# Altium schematic sheet RP2350A.SchDoc(RP2350A)
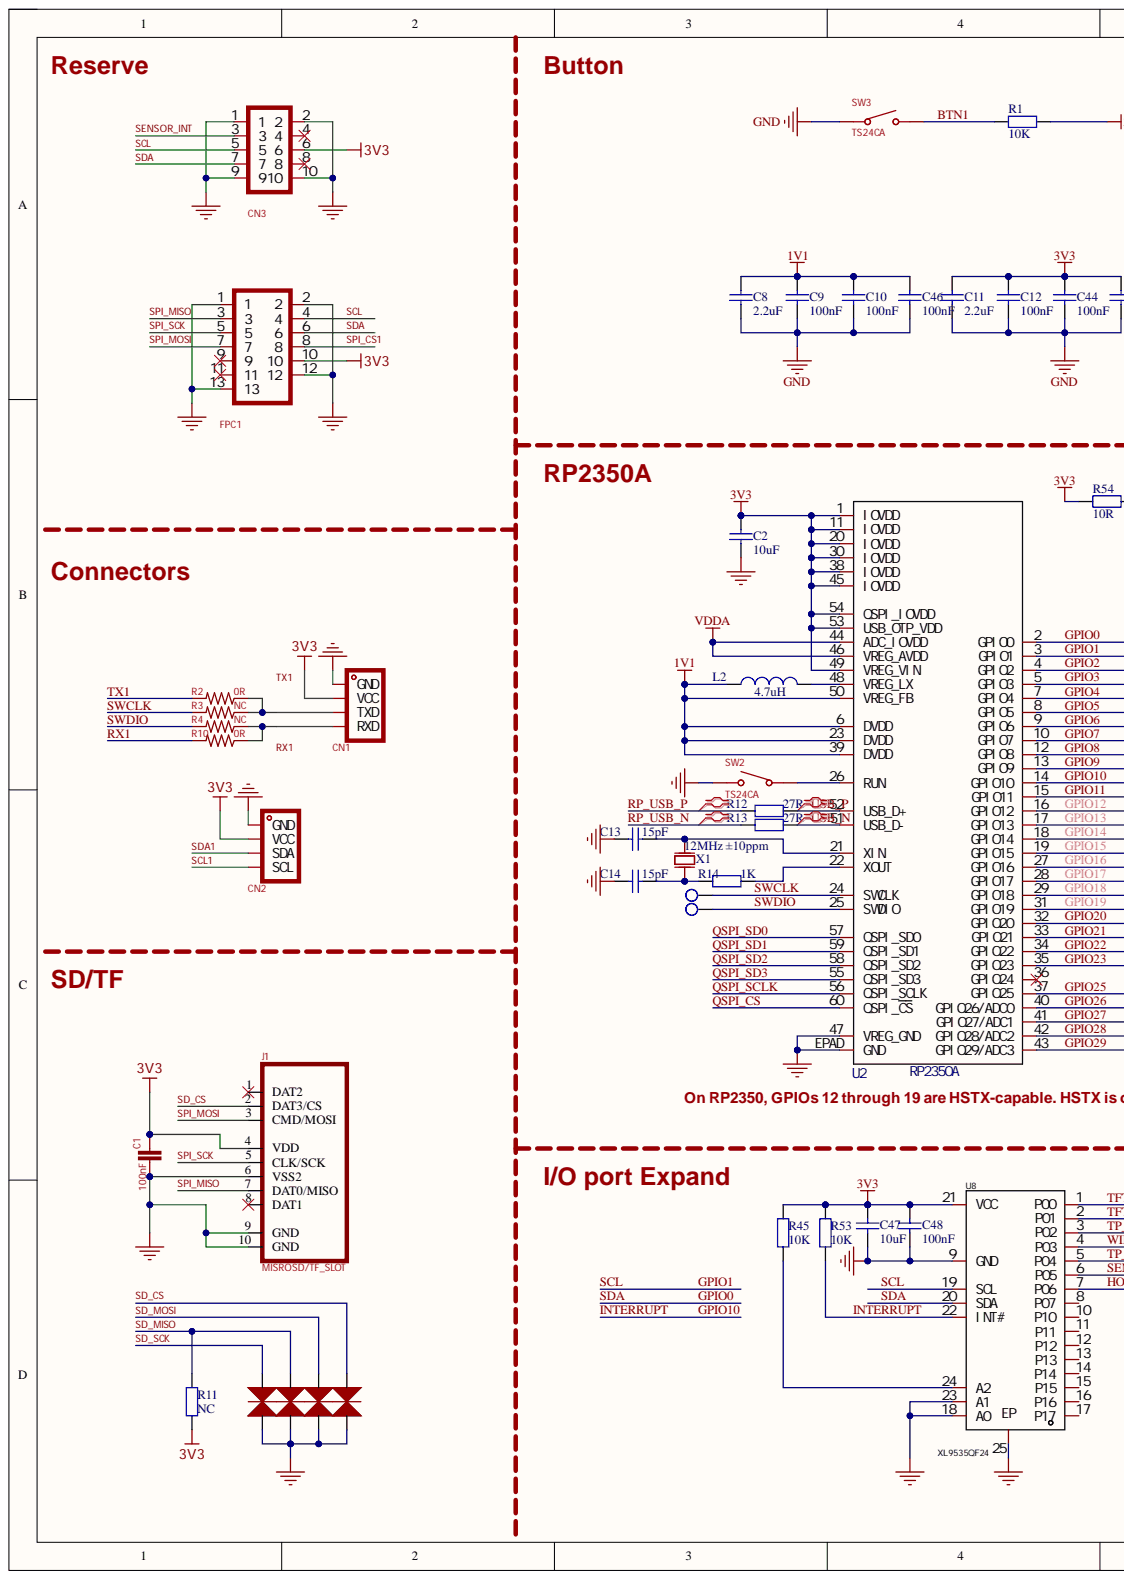
[diagram p0: RP2350A.SchDoc, left]
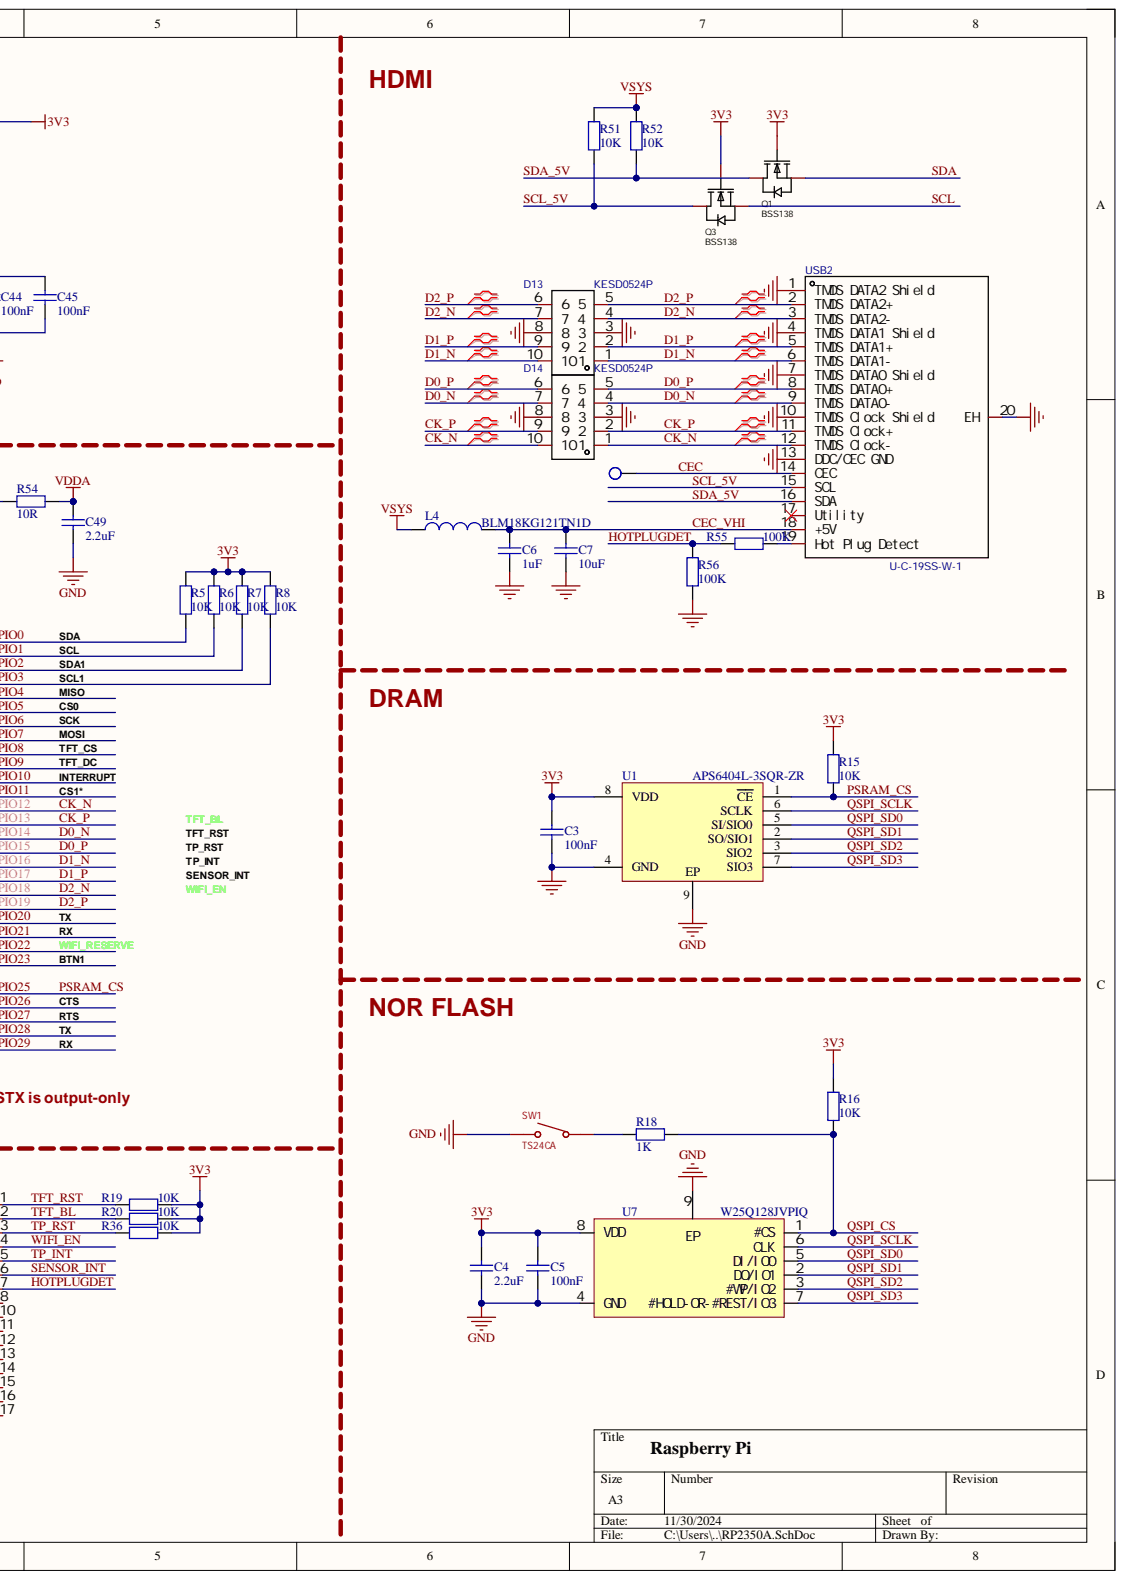
[diagram p1: RP2350A.SchDoc, right]

## Parts
C1  100nF  [C0402]
C2  10uF  [C0402]
C3  100nF  [C0402]
C4  2.2uF  [C0402]
C5  100nF  [C0402]
C6  1uF  [C0402]
C7  10uF  [C0402]
C8  2.2uF  [C0402]
C9  100nF  [C0402]
C10  100nF  [C0402]
C11  2.2uF  [C0402]
C12  100nF  [C0402]
C13  15pF  [C0402]
C14  15pF  [C0402]
C44  100nF  [C0402]
C45  100nF  [C0402]
C46  100nF  [C0402]
C47  10uF  [C0402]
C48  100nF  [C0402]
C49  2.2uF  [C0402]
CN1  BM04B-SRSS-TBT(LF)(SN)  [CONN-SMD_A1002WR-S-4P]  (value not drawn)
CN2  BM04B-SRSS-TBT(LF)(SN)  [CONN-SMD_A1002WR-S-4P]  (value not drawn)
CN3  DF37NC-10DS-0.4V(51)  [CONN-SMD_DF37NC-10DS-0.4V-51]  (value not drawn)
D1  ESD05V88D-LC  [DFN-SMD_L1.0-W0.6-BI]  (value not drawn)
D2  ESD05V88D-LC  [DFN-SMD_L1.0-W0.6-BI]  (value not drawn)
D3  ESD05V88D-LC  [DFN-SMD_L1.0-W0.6-BI]  (value not drawn)
D4  ESD05V88D-LC  [DFN-SMD_L1.0-W0.6-BI]  (value not drawn)
D13  KESD0524P  [DFN2510-10_L2.5-W1.0-P0.50-BL]
D14  KESD0524P  [DFN2510-10_L2.5-W1.0-P0.50-BL]
FPC1  FH26W-13S-0.3SHW(60)  [FPC-SMD_FH26W-13S-0.3SHW-60]  (value not drawn)
J1  MISROSD/TF_SLOT  [TF_5]
L2  4.7uH  [IND-SMD_L2.0-W1.6_FTC201610S]
L4  value BLM18KG121TN1D  [L0603]  (hidden comment: BLM18PG121SN1D)
Q1  BSS138  [SOT-23-3_L2.9-W1.3-P1.90-LS2.4-BR]
Q3  BSS138  [SOT-23-3_L2.9-W1.3-P1.90-LS2.4-BR]
R1  10K  [R0402]
R2  0R  [R0402]
R3  NC  [R0402]
R4  NC  [R0402]
R5  10K  [R0402]
R6  10K  [R0402]
R7  10K  [R0402]
R8  10K  [R0402]
R10  0R  [R0402]
R11  NC  [R0402]
R12  27R  [R0402]
R13  27R  [R0402]
R14  1K  [R0402]
R15  10K  [R0402]
R16  10K  [R0402]
R18  1K  [R0402]
R19  10K  [R0402]
R20  10K  [R0402]
R36  10K  [R0402]
R45  10K  [R0402]
R51  10K  [R0402]
R52  10K  [R0402]
R53  10K  [R0402]
R54  10R  [R0402]
R55  100K  [R0402]
R56  100K  [R0402]
SW1  TS24CA  [SW-SMD_TS24CA]
SW2  TS24CA  [SW-SMD_TS24CA]
SW3  TS24CA  [SW-SMD_TS24CA]
TEST1  测试点  [TP-1.00MM]  (value not drawn)
TEST2  测试点  [TP-1.00MM]  (value not drawn)
TEST3  测试点  [TP-1.00MM]  (value not drawn)
U1  APS6404L-3SQR-ZR  [USON-8_L3.0-W2.0-P0.50-BL-EP]
U2  RP2350A  [RP2350A]
U7  W25Q128JVPIQ  [WSON-8_L6.0-W5.0-P1.27-BL-EP]
U8  XL9535QF24  [QFN-24_L4.0-W4.0-P0.50-BL-EP2.6]
USB2  U-C-19SS-W-1  [MINI-HDMI-SMD_U-C-19SS-W-1]
X1  12MHz ±10ppm  [OSC-SMD_4P-L3.2-W2.5-BL_SIT8008BI]

## Nets
1V1: C8.1 C9.1 C10.1 C46.1 L2.1 U2.6(DVDD) U2.23(DVDD) U2.39(DVDD) U2.50(VREG_FB)
3V3: C1.2 C2.1 C3.1 C4.1 C5.1 C11.1 C12.1 C44.1 C45.1 C47.1 C48.1 CN1.2(VCC) CN2.2(VCC) CN3.6 FPC1.10 J1.4(VDD) Q1.1 Q3.1 R1.2 R5.2 R6.2 R7.2 R8.2 R11.1 R15.1 R16.1 R19.2 R20.1 R36.2 R45.2 R53.2 R54.1 U1.8(VDD) U2.1(IOVDD) U2.11(IOVDD) U2.20(IOVDD) U2.30(IOVDD) U2.38(IOVDD) U2.45(IOVDD) U2.49(VREG_VIN) U2.53(USB_OTP_VDD) U2.54(QSPI_IOVDD) U7.8(VDD) U8.21(VCC)
GND: C1.1 C2.2 C3.2 C4.2 C5.2 C6.2 C7.2 C8.2 C9.2 C10.2 C11.2 C12.2 C13.1 C14.1 C44.2 C45.2 C46.2 C47.2 C48.2 C49.2 CN1.1(GND) CN1.5 CN1.6 CN2.1(GND) CN2.5 CN2.6 CN3.1 CN3.2 CN3.9 CN3.10 D1.2 D2.2 D3.2 D4.2 D13.3 D13.8 D14.3 D14.8 FPC1.1 FPC1.2 FPC1.12 FPC1.13 FPC1.14 FPC1.15 J1.6(VSS2) J1.9(GND) J1.10(GND) R56.1 SW1.1 SW1.2 SW1.4 SW2.1 SW2.2 SW2.4 SW3.1 SW3.2 SW3.3 U1.4(GND) U1.9(EP) U2.47(VREG_GND) U2.EPAD(GND) U7.4(GND) U7.9(EP) U8.9(GND) U8.18(A0) U8.23(A1) U8.25(EP) USB2.1(TMDS) USB2.4(TMDS) USB2.7(TMDS) USB2.10(TMDS) USB2.13(DDC/CEC) USB2.20(EH) X1.2 X1.4
VDDA: C49.1 R54.2 U2.44(ADC_IOVDD) U2.46(VREG_AVDD)
VSYS: L4.1 R51.2 R52.2
BTN1: R1.1 SW3.4
CEC: TEST3.1 USB2.14(CEC)
CEC_VHI: C6.1 C7.1 L4.2 USB2.18(+5V)
CK_N: D14.1 D14.10 U2.16(GPIO12) USB2.12(TMDS)
CK_P: D14.2 D14.9 U2.17(GPIO13) USB2.11(TMDS)
D0_N: D14.4 D14.7 U2.18(GPIO14) USB2.9(TMDS)
D0_P: D14.5 D14.6 U2.19(GPIO15) USB2.8(TMDS)
D1_N: D13.1 D13.10 U2.27(GPIO16) USB2.6(TMDS)
D1_P: D13.2 D13.9 U2.28(GPIO17) USB2.5(TMDS)
D2_N: D13.4 D13.7 U2.29(GPIO18) USB2.3(TMDS)
D2_P: D13.5 D13.6 U2.31(GPIO19) USB2.2(TMDS)
GPIO0: CN3.7 FPC1.6 Q1.2 R5.1 U2.2(GPIO0) U8.20(SDA)
GPIO1: CN3.5 FPC1.4 Q3.2 R6.1 U2.3(GPIO1) U8.19(SCL)
GPIO2: R7.1 U2.4(GPIO2)
GPIO3: R8.1 U2.5(GPIO3)
GPIO4: U2.7(GPIO4)
GPIO5: U2.8(GPIO5)
GPIO6: U2.9(GPIO6)
GPIO7: U2.10(GPIO7)
GPIO8: U2.12(GPIO8)
GPIO9: U2.13(GPIO9)
GPIO10: R53.1 U2.14(GPIO10) U8.22(INT#)
GPIO11: U2.15(GPIO11)
GPIO20: U2.32(GPIO20)
GPIO21: U2.33(GPIO21)
GPIO22: U2.34(GPIO22)
GPIO23: U2.35(GPIO23)
GPIO25: R15.2 U1.1(CE) U2.37(GPIO25)
GPIO26: U2.40(GPIO26/ADC0)
GPIO27: U2.41(GPIO27/ADC1)
GPIO28: U2.42(GPIO28/ADC2)
GPIO29: U2.43(GPIO29/ADC3)
HOTPLUGDET: R55.2 R56.2 U8.7(P06)
QSPI_CS: R16.2 R18.2 U2.60(QSPI_CS) U7.1(#CS)
QSPI_SCLK: U1.6(SCLK) U2.56(QSPI_SCLK) U7.6(CLK)
QSPI_SD0: U1.5(SI/SIO0) U2.57(QSPI_SD0) U7.5(DI/IO0)
QSPI_SD1: U1.2(SO/SIO1) U2.59(QSPI_SD1) U7.2(DO/IO1)
QSPI_SD2: U1.3(SIO2) U2.58(QSPI_SD2) U7.3(#WP/IO2)
QSPI_SD3: U1.7(SIO3) U2.55(QSPI_SD3) U7.7(#HOLD-OR-#REST/IO3)
RP_USB_N: R13.2
RP_USB_P: R12.2
RX1: R10.2
SCL1: CN2.4(SCL)
SCL_5V: Q3.3 R51.1 USB2.15(SCL)
SD_CS: D4.1 J1.2(DAT3/CS)
SD_MISO: D2.1 R11.2
SD_MOSI: D3.1
SD_SCK: D1.1
SDA1: CN2.3(SDA)
SDA_5V: Q1.3 R52.1 USB2.16(SDA)
SENSOR_INT: CN3.3 U8.6(P05)
SPI_CS1: FPC1.8
SPI_MISO: FPC1.3 J1.7(DAT0/MISO)
SPI_MOSI: FPC1.7 J1.3(CMD/MOSI)
SPI_SCK: FPC1.5 J1.5(CLK/SCK)
SWCLK: R3.2 TEST1.1 U2.24(SWCLK)
SWDIO: R4.2 TEST2.1 U2.25(SWDIO)
TFT_BL: R20.2 U8.2(P01)
TFT_RST: R19.1 U8.1(P00)
TP_INT: U8.5(P04)
TP_RST: R36.1 U8.3(P02)
TX1: R2.2
USB_N: R13.1 U2.51(USB_D-)
USB_P: R12.1 U2.52(USB_D+)
WIFI_EN: U8.4(P03)
NetC13_2: C13.2 U2.21(XIN) X1.1
NetC14_2: C14.2 R14.2 X1.3
NetCN1_3: CN1.3(TXD) R2.1 R3.1
NetCN1_4: CN1.4(RXD) R4.1 R10.1
NetL2_2: L2.2 U2.48(VREG_LX)
NetR14_1: R14.1 U2.22(XOUT)
NetR18_1: R18.1 SW1.3
NetR45_1: R45.1 U8.24(A2)
NetR55_1: R55.1 USB2.19(Hot)
NetSW2_3: SW2.3 U2.26(RUN)

Not connected: CN3 4 8; FPC1 9 11; J1 1(DAT2) 8(DAT1); U2 36(GPIO24); U8 8(P07) 10(P10) 11(P11) 12(P12) 13(P13) 14(P14) 15(P15) 16(P16) 17(P17); USB2 17(Utility)

## Library data of the parts
C1 = C0201: 0201  贴片电容; Component Kind: Standard; Library Name: 常用.SCHLIB
C2-C14 C44-C49 = Cap: 贴片电阻; Component Kind: Standard; LatestRevisionDate: 17-Jul-2002; LatestRevisionNote: Re-released for DXP Platform.; Library Name: Miscellaneous Devices.IntLib; PackageDescription: Radial Cap, Thru-Hole; 2 Leads; 0.3 in Pin Spacing; PackageReference: RAD-0.3; Published: 8-Jun-2000; Signal Integrity: Cap; Simulation: CAP; Publisher: Altium Limited
  C2 C7 C47: Value: 10uF
  C3 C5 C9 C10 C12 C44-C46 C48: Value: 100nF
  C4 C8 C11 C49: Value: 2.2uF
  C6: Value: 1uF
  C13 C14: Value: 15pF
CN1 = BM04B-SRSS-TBT(LF)(SN): 1.0mm 1x4Pin 立贴; 系统型号: 暂无; Component Kind: Standard; 立创链接: https://item.szlcsc.com/506548.html; Library Name: T-Display_AMOLED-230601.SCHLIB
CN2 = BM04B-SRSS-TBT(LF)(SN)_IIC: 1.0mm 1x4Pin 立贴; 系统型号: 暂无; Component Kind: Standard; Library Name: 常用.SCHLIB; 立创链接: https://item.szlcsc.com/506548.html
CN3 = DF37NC-10DS-0.4V(51): PIN总数:10P;间距:0.4mm;行数:2;公母:母;安装方式:立贴;额定电流:300mA;工作温度范围:-35℃~+85℃;; Manufacturer: HRS(广濑); Add into BOM: yes; Current Rating (Max): 300mA; Gender: 母; Pitch: 0.4mm; Component Kind: Standard; Contact Plating: 金; Convert to PCB: yes; Datasheet: https://atta.szlcsc.com/upload/public/pdf/source/20181106/C324718_EE320AB13D4DE199EA9C8C02C118E7F5.pdf; Device: DF37NC-10DS-0.4V(51); JLCPCB Part Class: Extended Part; LCSC Part Name: PIN：10P 间距0.4mm 母 立贴; Library Name: RP2040.SCHLIB; Manufacturer Part: DF37NC-10DS-0.4V(51); Mounting Style: 立贴; Number of Pins: 10P; Number of Rows: 2; Operating Temperature Range: -35℃~+85℃; PCB3D: CONN-SMD_DF37NC-10DS-0.4V-51; Supplier: LCSC; Supplier Footprint: SMD,P=0.4mm; Supplier Part: C324718; Symbol: DF37NC-10DS-0.4V(51)
D1-D4 = ESD05V88D-LC: 系统型号: YLSMT101670; Component Kind: Standard; Library Name: 常用.SCHLIB; 立创链接: https://item.szlcsc.com/5751019.html
D13 D14 = KESD0524P: Manufacturer: KUU; Add into BOM: yes; Breakdown Voltage: 9V; Maximum Clamping Voltage: 15V; Number of Lines: 四路; Peak Pulse Current (Ipp)@10/1000us: 4A; Peak Pulse Power Dissipation (Ppp)@10/1000us: 60W; polarity: 单向; Reverse Leakage Current (Ir): 1uA; Reverse Stand-Off Voltage (Vrwm): 5V; Type: ESD; Component Kind: Standard; Convert to PCB: yes; Datasheet: https://atta.szlcsc.com/upload/public/pdf/source/20210908/7145E1A782369EF15DCFAAA18CBD8FC8.pdf; Device: KESD0524P; JLCPCB Part Class: Extended Part; LCSC Part Name: KESD0524P; Manufacturer Part: KESD0524P; Operating Temperature: -55℃~+125℃@(Tj); PCB3D: DFN-10_L2.5-W1.0-H0.5-P0.50; Supplier: LCSC; Supplier Footprint: DFN2510-10; Supplier Part: C2892488; Symbol: KESD0524P
FPC1 = FH26W-13S-0.3SHW(60): 间距:0.3mm;触点数量:13;锁定特性:翻盖式;安装类型:卧贴;触点类型:下接;; Manufacturer: HRS(广濑); Add into BOM: yes; FFC, FCB Thickness: 0.2mm; Locking Feature: 翻盖式; Number of Contacts: 13; Pitch: 0.3mm; Component Kind: Standard; Contact Type: 下接; Convert to PCB: yes; Device: FH26W-13S-0.3SHW(60); Height Above Board: 1mm; JLCPCB Part Class: Extended Part; LCSC Part Name: FPC,ZIF,FH26系列,0.3间距,13PIN,前翻盖; Library Name: RP2040.SCHLIB; Manufacturer Part: FH26W-13S-0.3SHW(60); Mounting Type: 卧贴; PCB3D: FPC-SMD_FH26W-13S-0.3SHW-60; Supplier: LCSC; Supplier Footprint: SMD,P=0.3mm; Supplier Part: C424653; Symbol: FH26W-13S-0.3SHW(60)
J1 = MISROSD/TF_SLOT: micro SD自弹式卡座  1.68H; 系统型号: YLSMT100809; Component Kind: Standard; Library Name: 常用.SCHLIB
L2 = Inductor: FTC201610S4R7MBCA; Code_IEC: 1005; Code_JEITA: 0402; Component Kind: Standard; LatestRevisionDate: 29-May-2009; LatestRevisionNote: IPC-7351 Footprint Added.; Library Name: Miscellaneous Devices.IntLib; PackageDescription: Chip Inductor; PackageReference: 0402-A; Published: 8-Jun-2000; Signal Integrity: Inductor; Simulation: INDUCTOR; Value: 4.7uH; Publisher: Altium Limited
L4 = Inductor: BLM18PG121SN1D/'120Ω@100MHz ±25%  0603; Code_IEC: 1005; Code_JEITA: 0402; Component Kind: Standard; LatestRevisionDate: 29-May-2009; LatestRevisionNote: IPC-7351 Footprint Added.; Library Name: Miscellaneous Devices.IntLib; PackageDescription: Chip Inductor; PackageReference: 0402-A; Published: 8-Jun-2000; Signal Integrity: Inductor; Simulation: INDUCTOR; Publisher: Altium Limited
Q1 Q3 = BSS138_C78284: Manufacturer: CJ(江苏长电/长晶); Add into BOM: yes; Continuous Drain Current (Id): 220mA; Drain Source On Resistance (RDS(on)@Vgs,Id): 3.5Ω@10V,220mA; Drain Source Voltage (Vdss): 50V; Gate Threshold Voltage (Vgs(th)@Id): 1.5V; Input Capacitance (Ciss@Vds): 27pF; Power Dissipation (Pd): 360mW; Reverse Transfer Capacitance (Crss@Vds): 6pF; Type: 1个N沟道; Component Kind: Standard; Convert to PCB: yes; Datasheet: https://atta.szlcsc.com/upload/public/pdf/source/20160713/1468379865905.pdf; Device: BSS138_C78284; JLCPCB Part Class: Extended Part; LCSC Part Name: 1个N沟道 耐压:50V 电流:220mA; Manufacturer Part: BSS138; PCB3D: SOT-23-3P_L2.9-W1.3-H1.0-LS2.4-P0.95; Supplier: LCSC; Supplier Footprint: SOT-23; Supplier Part: C78284; Symbol: BSS138
R1 R5-R8 R11-R16 R18-R20 R36 R45 R51-R56 = Res2: 电阻; Component Kind: Standard; LatestRevisionDate: 17-Jul-2002; LatestRevisionNote: Re-released for DXP Platform.; Library Name: Miscellaneous Devices.IntLib; PackageDescription: Axial Device, Thru-Hole; 2 Leads; 0.4 in Pin Spacing; PackageReference: AXIAL-0.4; Published: 8-Jun-2000; Signal Integrity: Res; Simulation: RESISTOR; Publisher: Altium Limited
  R1 R5-R8 R15 R16 R19 R20 R36 R45 R51-R53: Value: 10K
  R11: Value: NC
  R12 R13: Value: 27R
  R14 R18: Value: 1K
  R54: Value: 10R
  R55 R56: Value: 100K
R2-R4 R10 = R0402: 0402 贴片电阻; Component Kind: Standard; Library Name: 常用.SCHLIB
SW1-SW3 = TS24CA: 触点电流:50mA;额定电压(DC):12V;电路结构:单刀单掷;安装方式:卧贴;按钮形状:矩形按钮;带灯:-;开关长度:4.55mm;开关宽度:1.8mm;开关高度:3.5mm;; Manufacturer: SHOU HAN(首韩); Actuator Style: 矩形按钮; Add into BOM: yes; Circuit: 单刀单掷; Contact Current: 50mA; Switch Height: 3.5mm; Switch Length: 4.55mm; Switch Width: 1.8mm; Voltage Rating (DC): 12V; Component Kind: Standard; ComponentLink1Description: 立创链接; ComponentLink1URL: https://item.szlcsc.com/372554.html; Convert to PCB: yes; Datasheet: https://atta.szlcsc.com/upload/public/pdf/source/20190521/C393942_591A9E318887EFA4274D34029981AD99.pdf; Device: TS24CA; JLCPCB Part Class: Extended Part; LCSC Part Name: 2*4*3.5半包小贝贝TS-018小侧按键m165蓝牙耳机轻触开关; Library Name: 常用.SCHLIB; Manufacturer Part: TS24CA; Mounting Style: 卧贴; PCB3D: SW-SMD_TS24CA; Supplier: LCSC; Supplier Footprint: SMD; Supplier Part: C393942; Symbol: TS24CA; With Lamp: -
U2 = RP2350A: Add into BOM: yes; Device: RP2350A_RP2350A_; Component Kind: Standard; Convert to PCB: yes; Origin Footprint: RP2350A; Symbol: RP2350A_RP2350A_; Library Name: WendyLib.SchLib
U7 = W25Q128JVPIQ_C190862: Manufacturer: WINBOND(华邦); Add into BOM: yes; Memory Size: 128Mbit; Symbol: W25Q128JVPIQTR; Component Kind: Standard; Convert to PCB: yes; Datasheet: https://atta.szlcsc.com/upload/public/pdf/source/20190705/C401662_06B6D416F67ED315879B366F63A3F7C9.pdf; Device: W25Q128JVPIQ_C190862; JLCPCB Part Class: Extended Part; LCSC Part Name: W25Q128JVPIQ; Manufacturer Part: W25Q128JVPIQ; PCB3D: WSON-8_L6.0-W5.0-H0.7-P1.27; Supplier: LCSC; Supplier Footprint: WSON-8-EP(5x6); Supplier Part: C190862; Library Name: WendyLib.SchLib
U8 = XL9535QF24: Manufacturer: XINLUDA(信路达); Add into BOM: yes; Clock Frequency: 400kHz; Interface: I2C; Number of I/O: 16; Component Kind: Standard; Convert to PCB: yes; Datasheet: https://atta.szlcsc.com/upload/public/pdf/source/20221111/F6A27DC517C60CF7BC3ED74C539C74FA.pdf; Device: XL9535QF24; JLCPCB Part Class: Extended Part; LCSC Part Name: XL9535QF24; Manufacturer Part: XL9535QF24; PCB3D: QFN-24_L4.0-W4.0-H0.9-P0.50; Supplier: LCSC; Supplier Footprint: QFN-24(4x4); Supplier Part: C5444301; Symbol: XL9535QF24; Library Name: WendyLib.SchLib
USB2 = U-C-19SS-W-1: Manufacturer: 韩国韩荣; Add into BOM: yes; Connector Type: HDMI; Current Rating (Max): 500mA; Gender: 母; Component Kind: Standard; Convert to PCB: yes; Datasheet: https://atta.szlcsc.com/upload/public/pdf/source/20201126/C961763_6671A9D1E5A10A15F207EA55AE792CF8.pdf; Device: U-C-19SS-W-1; JLCPCB Part Class: Extended Part; LCSC Part Name: HDMI 母 P数:19P; Manufacturer Part: U-C-19SS-W-1; Mounting Style: 卧贴; Number of Pins: 19P; Number of Rows: -; Operating Temperature Range: -; PCB3D: MINI-HDMI-SMD_U-C-19SS-W-1; Supplier: LCSC; Supplier Footprint: SMD; Supplier Part: C961763; Symbol: U-C-19SS-W-1
X1 = SMD3225_40MHZ: X322540MPB4SI; Component Kind: Standard; ComponentLink1Description: 立创链接; ComponentLink1URL: https://item.szlcsc.com/9530.html; Library Name: 常用.SCHLIB
TEST1-TEST3 = 测试点: Component Kind: Standard; Library Name: WendyLib.SchLib
U1 = APS6404L-3SQR-ZR: Component Kind: Standard; Library Name: WendyLib.SchLib
